# Altium schematic sheet 9 Axis Sensor.SchDoc
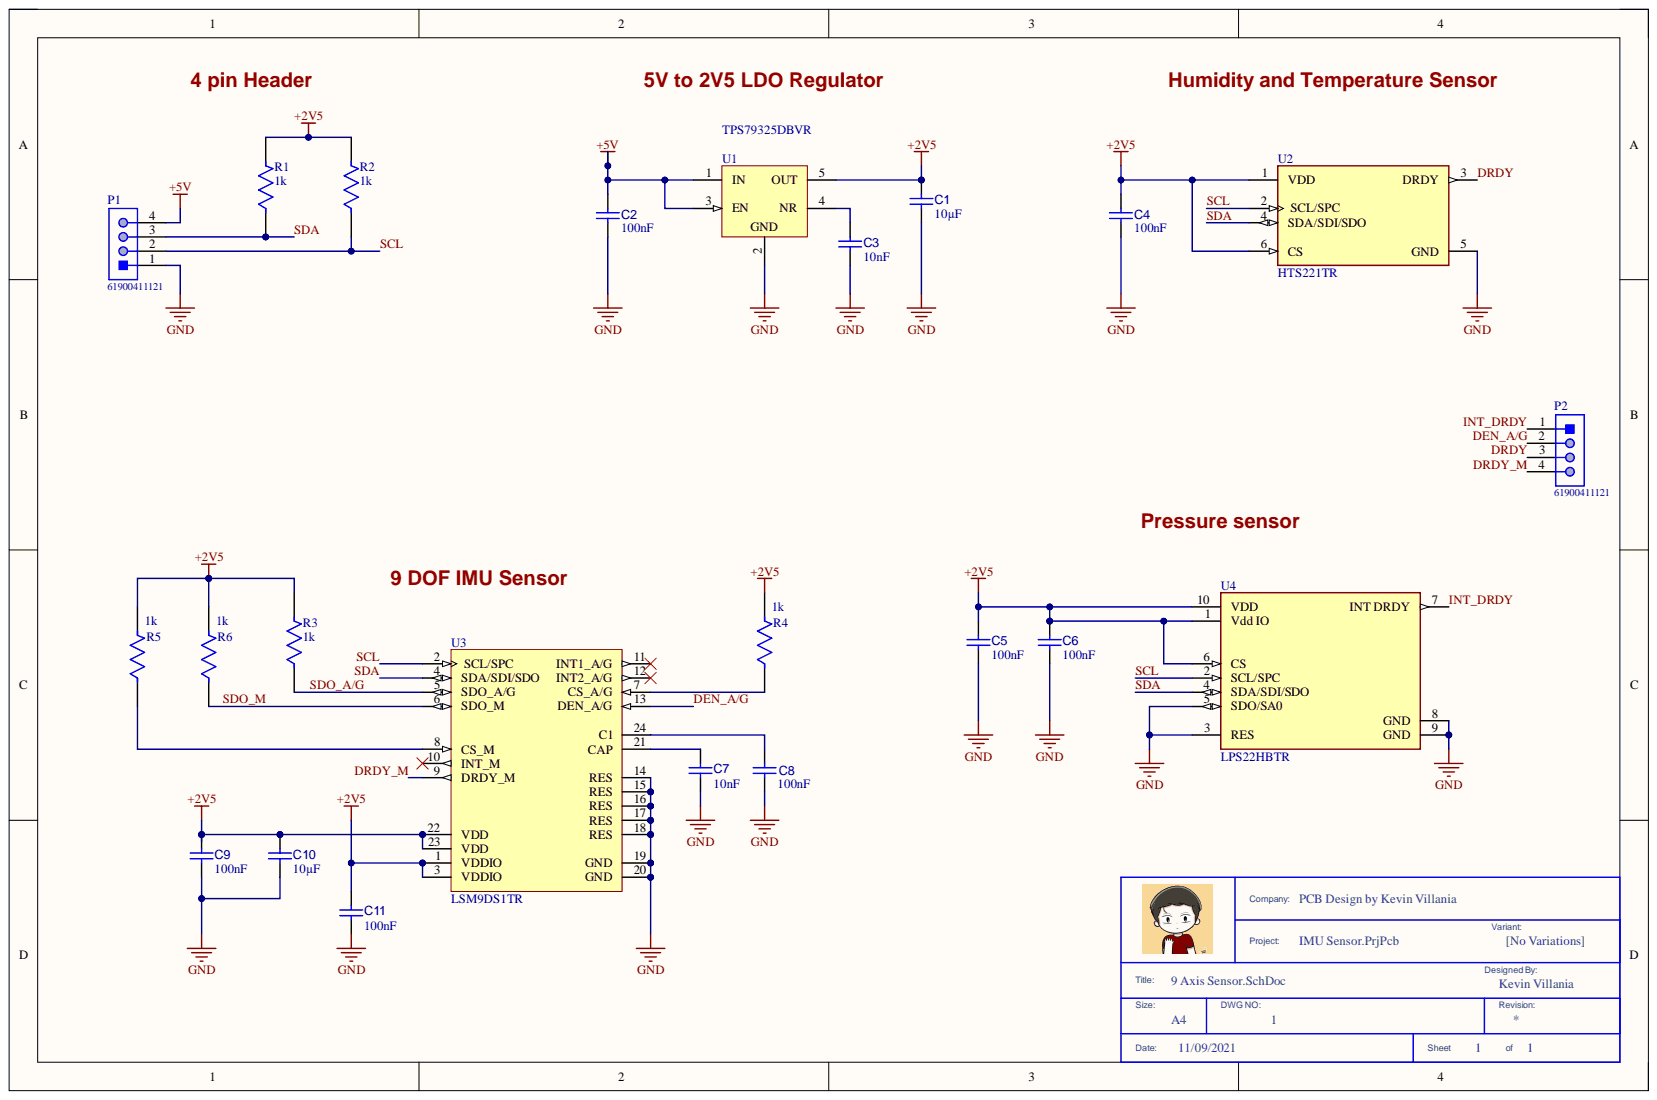
[diagram p0: 9 Axis Sensor.SchDoc]

## Parts
C1  value 10µF  [CAPC0402(1005)60_L]  (hidden comment: Capacitor 10µF +/-40% 6.3V 0402)
C2  value 100nF  [CAPC0402(1005)60_L]  (hidden comment: Capacitor 100nF +/-20% 10V 0402)
C3  value 10nF  [CAPC0402(1005)60_L]  (hidden comment: Capacitor 10nF +/-20% 50V 0402)
C4  value 100nF  [CAPC0402(1005)60_L]  (hidden comment: Capacitor 100nF +/-20% 10V 0402)
C5  value 100nF  [CAPC0402(1005)60_L]  (hidden comment: Capacitor 100nF +/-20% 10V 0402)
C6  value 100nF  [CAPC0402(1005)60_L]  (hidden comment: Capacitor 100nF +/-20% 10V 0402)
C7  value 10nF  [CAPC0402(1005)60_L]  (hidden comment: Capacitor 10nF +/-20% 50V 0402)
C8  value 100nF  [CAPC0402(1005)60_L]  (hidden comment: Capacitor 100nF +/-20% 10V 0402)
C9  value 100nF  [CAPC0402(1005)60_L]  (hidden comment: Capacitor 100nF +/-20% 10V 0402)
C10  value 10µF  [CAPC0603(1608)100_L]  (hidden comment: Capacitor 10µF +/-20% 10V 0603)
C11  value 100nF  [CAPC0402(1005)60_L]  (hidden comment: Capacitor 100nF +/-20% 10V 0402)
P1  61900411121  [61900411121]
P2  61900411121  [61900411121]
R1  1k  [FP-RC1005-IPC_A]
R2  1k  [FP-RC1005-IPC_A]
R3  1k  [FP-RC1005-IPC_A]
R4  1k  [FP-RC1005-IPC_A]
R5  1k  [FP-RC1005-IPC_A]
R6  1k  [FP-RC1005-IPC_A]
U1  TPS79325DBVR  [DBV0005A_M]
U2  HTS221TR  [FP-HTS221TR-MFG]
U3  LSM9DS1TR  [STM-LGA-24_3500X3000X1000]
U4  LPS22HBTR  [FP-HLGA-10L-8479831-0]

## Nets
+2V5: C1.2 C4.1 C5.1 C6.1 C9.1 C10.2 C11.1 R1.2 R2.2 R3.2 R4.2 R5.2 R6.2 U1.5(OUT) U2.1(VDD) U2.6(CS) U3.1(VDDIO) U3.3(VDDIO) U3.22(VDD) U3.23(VDD) U4.1(Vdd_IO) U4.6(CS) U4.10(VDD)
+5V: C2.1 P1.4 U1.1(IN) U1.3(EN)
GND: C1.1 C2.2 C3.1 C4.2 C5.2 C6.2 C7.1 C8.2 C9.2 C10.1 C11.2 P1.1 U1.2 U2.5(GND) U3.14(RES) U3.15(RES) U3.16(RES) U3.17(RES) U3.18(RES) U3.19(GND) U3.20(GND) U4.3(RES) U4.5(SDO/SA0) U4.8(GND) U4.9(GND)
DEN_A/G: P2.2 U3.13(DEN_A/G)
DRDY: P2.3 U2.3(DRDY)
DRDY_M: P2.4 U3.9(DRDY_M)
INT_DRDY: P2.1 U4.7(INT_DRDY)
SCL: P1.2 R2.1 U2.2(SCL/SPC) U3.2(SCL/SPC) U4.2(SCL/SPC)
SDA: P1.3 R1.1 U2.4(SDA/SDI/SDO) U3.4(SDA/SDI/SDO) U4.4(SDA/SDI/SDO)
SDO_A/G: R3.1 U3.5(SDO_A/G)
SDO_M: R6.1 U3.6(SDO_M)
NetC3_2: C3.2 U1.4(NR)
NetC7_2: C7.2 U3.21(CAP)
NetC8_1: C8.1 U3.24(C1)
NetR4_1: R4.1 U3.7(CS_A/G)
NetR5_1: R5.1 U3.8(CS_M)

Not connected: U3 10(INT_M) 11(INT1_A/G) 12(INT2_A/G)

## Library data of the parts
C1 = CMP-001-00074-3: Chip Capacitor, 10µF +/-40%, 6.3V, 0402, Thickness 0.6 mm; Tolerance: 10%; Case/Package: 0402; Component Kind: Standard; Max Operating Temperature: 85°C; Min Operating Temperature: -55°C; Mounting Technology: SMT; Pins: 2; Voltage Rating: 6.3V; Library Name: Polytechnic University of the Philippines
C2 C4-C6 C8 C9 C11 = CMP-001-00061-3: Chip Capacitor, 100nF +/-20%, 10V, 0402, Thickness 0.6 mm; Tolerance: 20%; Case/Package: 0402; Component Kind: Standard; Max Operating Temperature: 85°C; Min Operating Temperature: -55°C; Mounting Technology: SMT; Pins: 2; Voltage Rating: 10V; Library Name: Polytechnic University of the Philippines
C3 C7 = CMP-001-00069-3: Chip Capacitor, 10nF +/-20%, 50V, 0402, Thickness 0.6 mm; Tolerance: 5%; Case/Package: 0402; Component Kind: Standard; Max Operating Temperature: 85°C; Min Operating Temperature: -55°C; Mounting Technology: SMT; Pins: 2; Voltage Rating: 50V; Library Name: Polytechnic University of the Philippines
C10 = CMP-001-00075-4: Chip Capacitor, 10µF +/-20%, 10V, 0603, Thickness 1 mm; Tolerance: 10%; Case/Package: 0603; Component Kind: Standard; Max Operating Temperature: 85°C; Min Operating Temperature: -55°C; Mounting Technology: SMT; Pins: 2; Voltage Rating: 10V; Library Name: Polytechnic University of the Philippines
P1 P2 = CMP-1502-00586-1: Male Locking Header WR-WTB, THT, Vertical, pitch 2.54 mm, 1 x 4 position; Manufacturer: Wurth Elektronik; Contact Resistance: 20mOhm; Pitch: 2.54mm; Position: 1x4; Working Voltage: 250VAC; Component Kind: Standard; Datasheet URL: https://katalog.we-online.de/em/datasheet/6190xx11121.pdf; Gender: Male; Library Name: Altium Content Vault; Manufacturer URL: http://www.we-online.de; Mounting Technology: THT; Operating Temperature: -40to105degC; Orientation: Vertical; Package Reference: 61900411121; Packaging: Bag; Part Number: 61900411121; Publisher: WurthElektronik
R1-R6 = CMP-13261-004451-1: Res Thick Film 0402 1kΩ 1% 0.063W ±100ppm/°C Molded SMD T/R; Max Power Dissipation: 63mW; Resistance: 1kR; Voltage Rating (DC): 50V; Case Code (Imperial): 0402; Case Code (Metric): 1005; Case/Package: 0402; Component Kind: Standard; Composition: Thick Film; Contact Plating: Tin; Depth: 0.5mm; Features: Moisture Resistant; Height: 0.016inch; Lead Free: Lead Free; Length: 1mm; Library Name: Altium Content Vault; Max Operating Temperature: 155°C; Military Standard: Not; Min Operating Temperature: -55°C; Number of Terminations: 2; Packaging: Tape and Reel; Radiation Hardening: No; Temperature Coefficient: 100ppm/°C; Tolerance: 1%; Width: 0.02inch
U1 = CMP-0394-00287-2: Single Output High PSRR LDO, 200 mA, Fixed 2.5 V Output, 2.7 to 5.5 V Input, 5-pin SOT-23 (DBV), -40 to 85 degC, Green (RoHS & no Sb/Br); Manufacturer: Texas Instruments; Accuracy(%): 2; Fixed Output Options(V): 2.5; Iout(Max)(A): 0.2; Rating: Catalog; SubFamily: Single Channel LDO; Vdo(Typ)(mV): 112; Vin(Max)(V): 5.5; Vin(Min)(V): 2.7; Code_JEDEC: MO-178; Component Kind: Standard; ComponentLink1Description: Manufacturer URL; ComponentLink1URL: http://www.ti.com/; ComponentLink2Description: Datasheet; ComponentLink2URL: http://www.ti.com/general/docs/lit/getliterature.tsp?genericPartNumber=TPS79325&fileType=pdf; ComponentLink3Description: Package Specification; ComponentLink3URL: http://www.ti.com/litv/pdf/mpds018i; DatasheetVersion: SLVS348K; Eco-Plan: Green (RoHS & no Sb/Br); Iq(Typ)(mA): 0.2; Library Name: Altium Content Vault; Mounting Technology: Surface Mount; PackageDescription: 5-Pin Plastic Small Outline, Body 1.60 Ãƒâ€” 2.90 mm, Pitch 0.95 mm; PackageReference: DBV0005A; PackageVersion: 4073253-4/K; PartNumber: TPS79325DBVR; Vout Adj(Max)(V): 2.5; Vout Adj(Min)(V): 2.5
U2 = CMP-2000-06428-2: SENS HUMI/TEMP 2.5V I2C 4.5% SMD; Operating Supply Voltage: 3.6V; Output Type: SPI; Resolution: 16b; Response Time: 10s; Sensor Type: Temperature; Accuracy: 4.5%; Component Kind: Standard; Interface: I2C; Lead Free: Lead Free; Library Name: Altium Content Vault; Max Operating Temperature: 120°C; Min Operating Temperature: -40°C; Mount: Surface Mount; Number of Pins: 6; Packaging: Tape and Reel; Radiation Hardening: No
U3 = CMP-2000-06606-1: iNEMO Inertial Module: 3D Accelerometer, 3D Gyroscope, 3D Magnetometer, 1.9 to 3.6 V, -40 to 85 degC, 24-Pin LGA, RoHS, Tape and Reel; Manufacturer: STMicroelectronics; Acceleration Range: ±2g,±4g,±8g,±16g; Gyroscope Range: ±245°/s,±500°/s,±2000°/s; MEMS Module Function: Tri-AxisGyroscope,Tri-AxisAccelerometer,Tri-AxisMagnetometer; No. of Pins: 24Pins; Supply Voltage Max: 3.6V; Supply Voltage Min: 1.9V; SVHC: NoSVHC(17-Dec-2015); Component Kind: Standard; Datasheet URL: http://www2.st.com/content/ccc/resource/technical/document/datasheet/1e/3f/2a/d6/25/eb/48/46/DM00103319.pdf/files/DM00103319.pdf/jcr:content/translations/en.DM00103319.pdf; Datasheet Version: Rev. 3, 03/2015; Library Name: Altium Content Vault; Manufacturer URL: http://www.st.com/; Mounting Technology: SurfaceMount; MSL: MSL3-168hours; Package Description: 24-Pin Plastic Land Grid Array Package, Body 3.65 x 3.15 mm, Pitch 0.43 mm; Package Reference: LGA-24_3500X3000X1000; Packaging: CutTape; PartNumber: LSM9DS1; Product Range: -; Sensor Case Style: LGA
U4 = CMP-12182-000004-1: MEMS NANO PRESSURE SENSOR: 260-1; Manufacturer: STMicroelectronics; Max Supply Voltage: 3.6V; Min Supply Voltage: 1.7V; Operating Supply Current: 3-12uA; Port Style: No Port; Sensor Output: I2C,SPI; Automotive: No; Category: IC; Component Kind: Standard; ComponentLink2Description: Datasheet; ComponentLink2URL: https://www.st.com/resource/en/datasheet/lps22hb.pdf; Device Class L1: Sensors; Device Class L2: Pressure Sensors; Device Class L3: unset; Digikey Description: MEMS NANO PRESSURE SENSOR: 260-1; Footprint Url: https://www.st.com/content/ccc/resource/technical/document/technical_note/73/17/9c/16/dd/96/4a/01/CD00134799.pdf/files/CD00134799.pdf/jcr:content/translations/en.CD00134799.pdf; Height: 0.8mm; Lead Free: Yes; Library Name: Altium Content Vault; Manufacturer Part Number: LPS22HBTR; Octopart: https://octopart.com/lps22hbtr-stmicroelectronics-51851131; Package: HLGA-10L-8479831; RoHS: TRUE; Temperature: -40°C to +85°C; Temperature Range High: +85°C; Temperature Range Low: -40°C
